# Altium schematic sheet MCP3561R_ADC.SchDoc(MCP3561R_ADC)
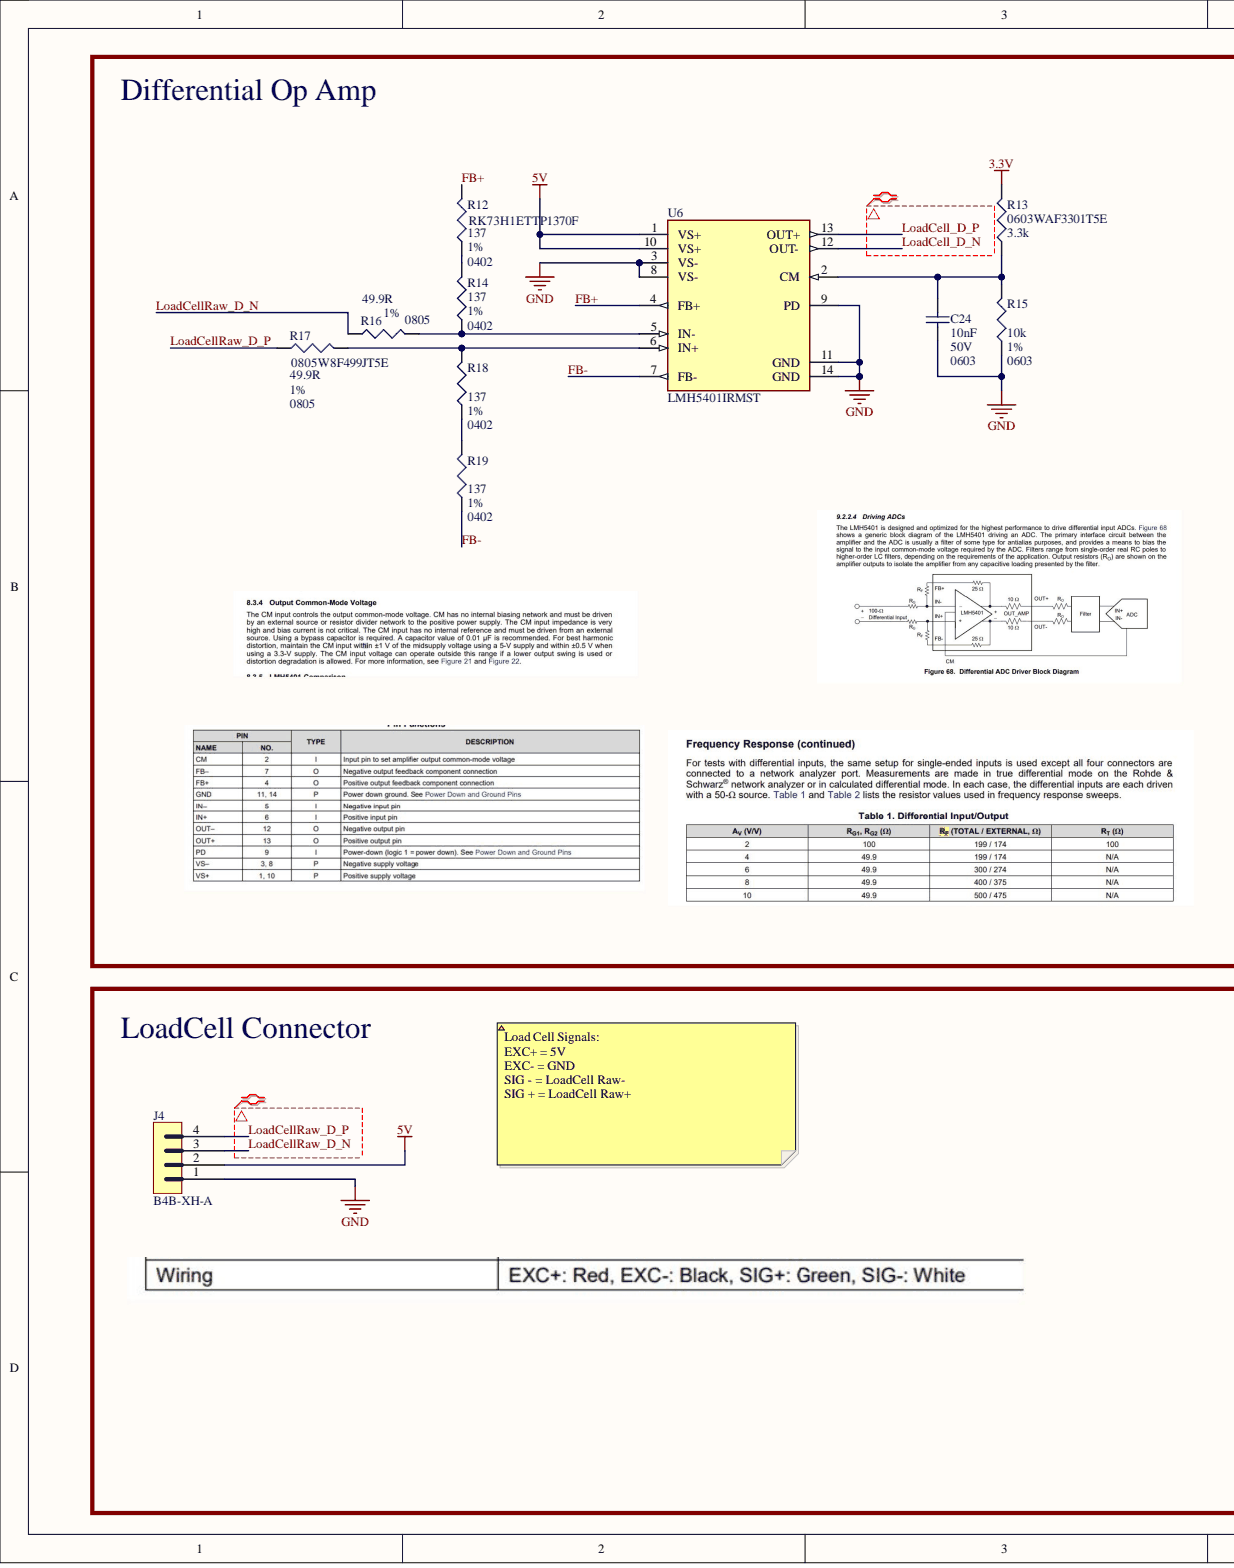
[diagram p0: MCP3561R_ADC.SchDoc, left]
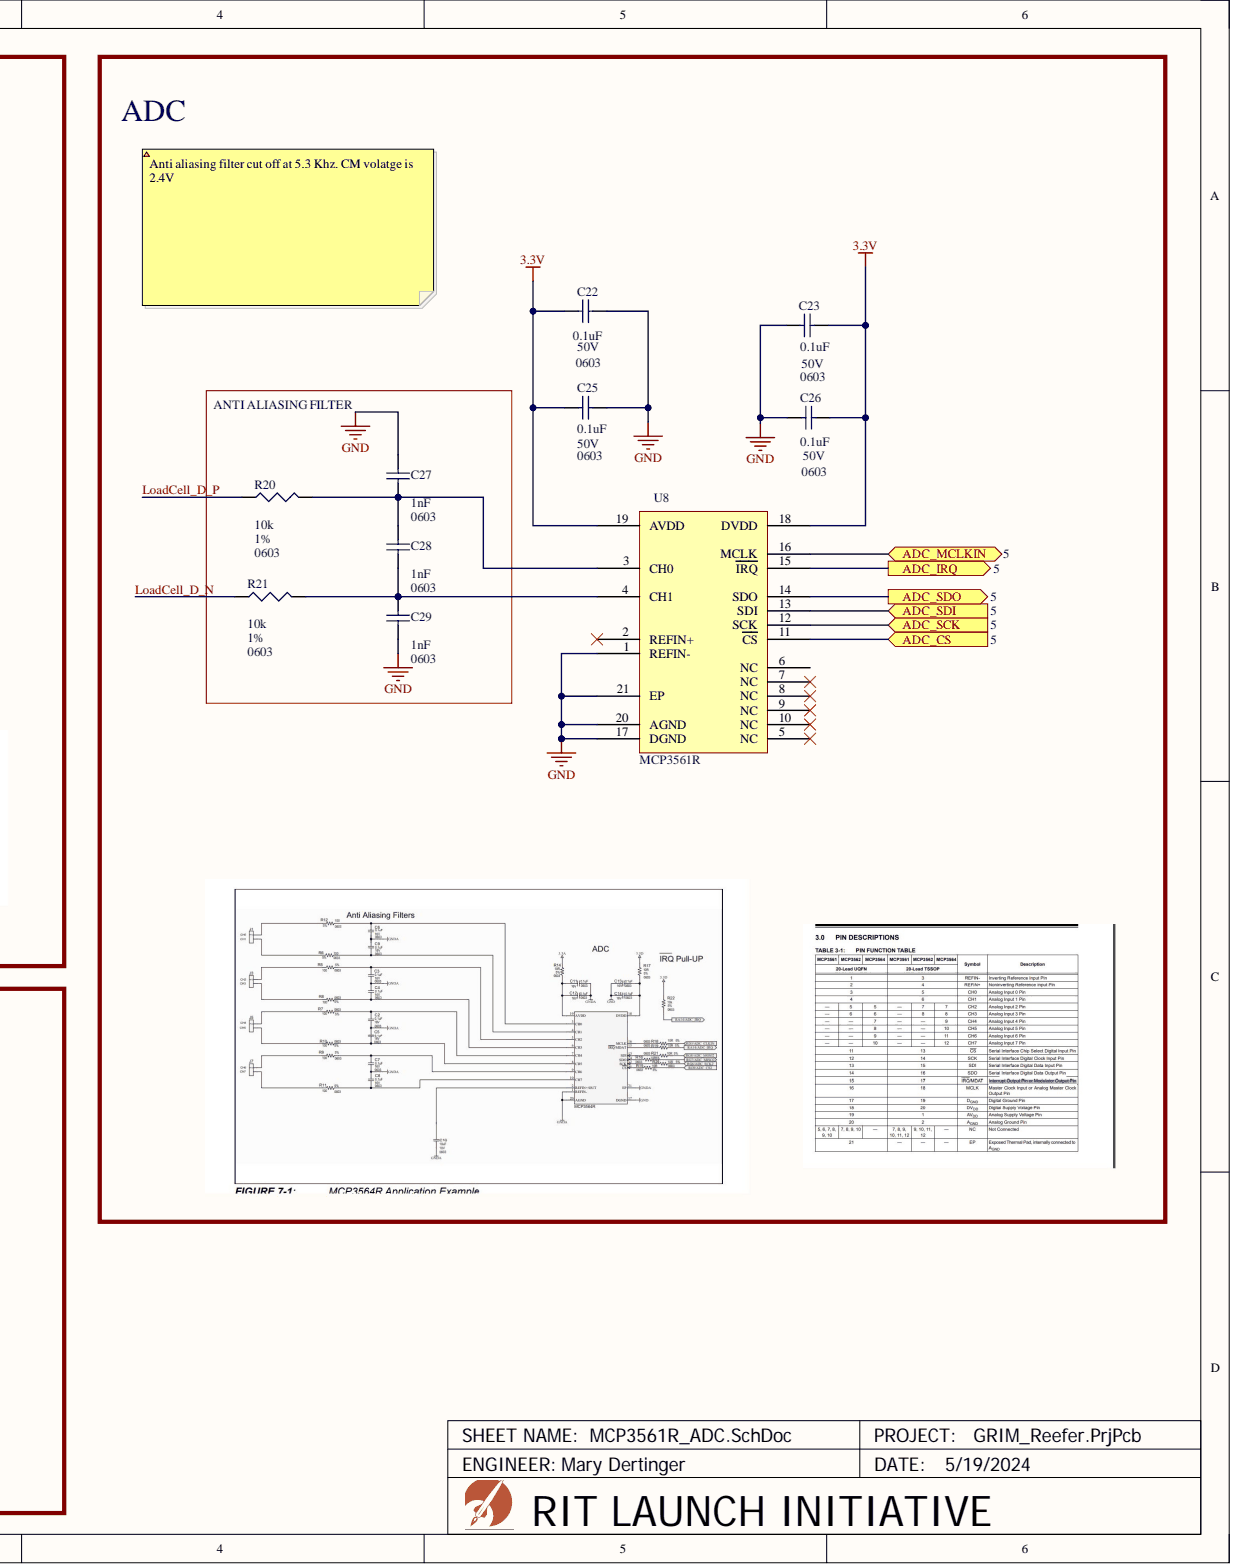
[diagram p1: MCP3561R_ADC.SchDoc, right]

## Parts
C22  Capacitance: 0.1uF  Voltage: 50V  (hidden comment: CC0603KRX7R9BB104)
C23  Capacitance: 0.1uF  Voltage: 50V  (hidden comment: CC0603KRX7R9BB104)
C24  Capacitance: 10nF  Case/Package: 0603  Voltage: 50V  (hidden comment: 0603B103K500NT)
C25  Capacitance: 0.1uF  Case Code (Imperial): 0603  Voltage: 50V  (hidden comment: CC0603KRX7R9BB104)
C26  Capacitance: 0.1uF  Case Code (Imperial): 0603  Voltage: 50V  (hidden comment: CC0603KRX7R9BB104)
C27  Capacitance: 1nF  (hidden comment: CL10B102KB8NNNC)
C28  Capacitance: 1nF  Case/Package: 0603  (hidden comment: CL10B102KB8NNNC)
C29  Capacitance: 1nF  Case/Package: 0603  (hidden comment: CL10B102KB8NNNC)
J4  B4B-XH-A
R12  RK73H1ETTP1370F  Case/Package: 0402  Tolerance: 1%
R13  0603WAF3301T5E  Resistance: 3.3k
R14  RK73H1ETTP1370F  Case/Package: 0402  Tolerance: 1%
R15  Case/Package: 0603  Resistance: 10k  Tolerance: 1%  (hidden comment: 0603WAF1002T5E)
R16  0805W8F499JT5E  Case/Package: 0805  Resistance: 49.9R  Tolerance: 1%
R17  0805W8F499JT5E  Resistance: 49.9R  Tolerance: 1%
R18  RK73H1ETTP1370F  Case/Package: 0402  Tolerance: 1%
R19  RK73H1ETTP1370F  Case/Package: 0402  Tolerance: 1%
R20  Resistance: 10k  Tolerance: 1%  (hidden comment: 0603WAF1002T5E)
R21  Case/Package: 0603  Resistance: 10k  Tolerance: 1%  (hidden comment: 0603WAF1002T5E)
U6  LMH5401IRMST
U8  MCP3561R

## Ports
ADC_CS, ADC_IRQ, ADC_MCLKIN, ADC_SCK, ADC_SDI, ADC_SDO

## Nets
3.3V: C22.1 C23.2 C25.1 C26.2 R13.2 U8.18(DVDD) U8.19(AVDD)
5V: J4.2 U6.1(VS+) U6.10(VS+)
GND: C22.2 C23.1 C24.1 C25.2 C26.1 C27.2 C29.1 J4.1 R15.1 U6.3(VS-) U6.8(VS-) U6.9(PD) U6.11(GND) U6.14(GND) U8.1(REFIN-) U8.17(DGND) U8.20(AGND) U8.21(EP)
ADC_CS: U8.11(CS) ; port ADC_CS
ADC_IRQ: U8.15(IRQ) ; port ADC_IRQ
ADC_MCLKIN: U8.16(MCLK) ; port ADC_MCLKIN
ADC_SCK: U8.12(SCK) ; port ADC_SCK
ADC_SDI: U8.13(SDI) ; port ADC_SDI
ADC_SDO: U8.14(SDO) ; port ADC_SDO
FB+: R12.2 U6.4(FB+)
FB-: R19.1 U6.7(FB-)
LoadCell_D_N: R21.1 U6.12(OUT-)
LoadCell_D_P: R20.1 U6.13(OUT+)
LoadCellRaw_D_N: J4.3 R16.1
LoadCellRaw_D_P: J4.4 R17.1
NetC24_2: C24.2 R13.1 R15.2 U6.2(CM)
NetC27_1: C27.1 C28.2 R20.2 U8.3(CH0)
NetC28_1: C28.1 C29.2 R21.2 U8.4(CH1)
NetR12_1: R12.1 R14.2
NetR14_1: R14.1 R16.2 U6.5(IN-)
NetR17_2: R17.2 R18.2 U6.6(IN+)
NetR18_1: R18.1 R19.2

Not connected: U8 2(REFIN+) 5(NC) 6(NC) 7(NC) 8(NC) 9(NC) 10(NC)

## Library data of the parts
C22 C23 C25 C26 = CMP-001-0242-3: CAP CER 0.1UF 50V X7R 0603; Dielectric Material: Ceramic; Tolerance: 10%; Case Code (Metric): 1608; Case/Package: 0603; Component Kind: Standard; Depth: 0.8mm; Dielectric: X7R; Halogen Free: Halogen Free; Height: 0.8mm; JLCPCB Part #: C14663; Lead Free: Lead Free; Length: 0.063inch; Max Operating Temperature: 125°C; Min Operating Temperature: -55°C; Mount: Surface Mount; Number of Pins: 2; Package Quantity: 1; Packaging: Tape and Reel; Radiation Hardening: No; REACH SVHC: No SVHC; RoHS Compliant: Yes; Series: CC; Termination: SMD/SMT; Thickness: 0.035inch; Voltage Rating: 50V; Width: 0.031inch; Library Name: RIT Launch Initiative
  C22 C23: Case Code (Imperial): 0603
C24 = CMP-001-0273-1: CAP CER 10000PF 50V X7R 0603; Component Kind: Standard; JLCPCB Part #: C57112; Library Name: RIT Launch Initiative
C27-C29 = CMP-001-0398-1: Ceramic Capacitor, Multilayer, Ceramic, 50V, 10% +Tol, 10% -Tol, X7R, 15% TC, 0.001uF, Surface Mount, 0603; Multilayer: Yes; Capacitor Type: Ceramic; Component Kind: Standard; Dielectric Material: Ceramic; Height: 0.9mm; JESD-609 Code: e3; JLCPCB Part #: C1588; Length: 1.6mm; Max Operating Temperature: 125°C; Min Operating Temperature: -55°C; Mounting Technology: Surface Mount; Package Shape: Rectangular; Package Style: SMTMeter; Packaging: Tape and Reel; Pins: 2; Rated DC Voltage (URdc): 50V; Reach SVHC Compliant: Yes; RoHS Compliant: Yes; Temperature Characteristics Code: X7R; Terminal Finish: Nickel; Termination: Wraparound; Tolerance  (fill in as +-): 10%; Tolerance (fill in as +-): 10%; Width: 0.8mm; Library Name: RIT Launch Initiative
  C27: Case/Package: 0603
J4 = CMP-001-0397-1: CONN HEADER VERT 4POS 2.5MM; Component Kind: Standard; Library Name: RIT Launch Initiative
R12 R14 R18 R19 = CMP-001-0391-2: Fixed Resistor, Metal Glaze/thick Film, 0.1W, 137ohm, 75V, 1% +/-Tol, 100ppm/Cel, Surface Mount, 0402; Additional Feature: PRECISION; Package Height: 0.35mm; Package Length: 1mm; Package Width: 0.5mm; Power: 100mW; Rated Temperature: 70°C; Reference Standard: AEC-Q200; Resistance: 137; Temperature Coefficient: 100ppm/°C; Value: 137R; Working Voltage: 50V; Component Kind: Standard; Construction: Rectangular; JESD-609 Code: e3; JLCPCB Part #: C316926; Max Operating Temperature: 155°C; Min Operating Temperature: -55°C; Mounting Technology: Surface Mount; Package Style: SMTMeter; Packaging: Tape and Reel; Pins: 2; Reach SVHC Compliant: Yes; Resistor Type: FIXED RESISTOR; RoHS Compliant: Yes; Technology: METAL GLAZE/THICK FILM; Terminal Finish: Nickel; Termination: Wraparound; Library Name: RIT Launch Initiative
R13 = CMP-001-0414-1: Fixed Resistor, Metal Glaze/thick Film, 0.1W, 3300ohm, 75V, 1% +/-Tol, 100ppm/Cel, Surface Mount, 0603; Component Kind: Standard; JLCPCB Part #: C22978; Package: 0603; Reach SVHC Compliant: Yes; RoHS Compliant: Yes; Library Name: RIT Launch Initiative
R15 R20 R21 = CMP-001-0268-1: RES 10K OHM 1% 1/10W 0603; Component Kind: Standard; JLCPCB Part #: C25804; RoHS Compliant: Yes; Library Name: RIT Launch Initiative
  R20: Case/Package: 0603
R16 R17 = CMP-001-0415-2: Fixed Resistor, Metal Glaze/thick Film, 0.125W, 49.9ohm, 150V, 1% +/-Tol, 200ppm/Cel, Surface Mount, 0805; Manufacturer Series: 0805W8; Power: 125mW; Rated Temperature: 70°C; Temperature Coefficient: 200ppm/°C; Component Kind: Standard; JESD-609 Code: e3; JLCPCB Part #: C17720; Max Operating Temperature: 155°C; Min Operating Temperature: -55°C; Mounting Technology: Surface Mount; Package Shape: Rectangular; Packaging: Tape and Reel; Pins: 2; Resistor Type: FIXED RESISTOR; RoHS Compliant: Yes; Technology: METAL GLAZE/THICK FILM; Terminal Finish: Tin; Termination: Wraparound; Working Voltage: 150V; Library Name: RIT Launch Initiative
  R17: Case/Package: 0805
U6 = CMP-001-0375-3: Operational Amplifier, 1 Func, 5000uV Offset-Max, 4400MHz Band Width, BICMOS; Channels: 1; Common-mode Reject Ratio-Nom: 72dB; Nominal Supply Voltage: 5V; Slew Rate: 17500V/µs; Supply Voltage Limit-Max: 5.5V; Unity Gain BW-Nom: 8GHz; Amplifier Type: OPERATIONAL AMPLIFIER; Case/Package: VQCCN; Component Kind: Standard; Height - Seated (Max): 0.6mm; JESD-609 Code: e3; JLCPCB Part #: C2057542; Length: 2.5mm; Max Operating Temperature: 85°C; Min Operating Temperature: -40°C; Moisture Sensitivity Level: 2; Package Shape: Square; Package Style: CHIP CARRIER, VERY THIN PROFILEMeter; Pins: 14; Reach SVHC Compliant: Yes; RoHS Compliant: Yes; Surface Mount: Yes; Technology: BICMOS; Temperature Grade: INDUSTRIAL; Terminal Finish: Tin; Terminal Form: No Lead; Terminal Pitch: 0.5mm; Terminal Position: QUAD; Width: 2.5mm; Library Name: RIT Launch Initiative
U8 = CMP-001-0373-8: ADC, Delta-Sigma, 24-Bit, 1 Func, 1 Channel, Serial Access, PDSO20; Component Kind: Standard; Data SHeet: https://ww1.microchip.com/downloads/en/DeviceDoc/MCP3561.2.4R-Data-Sheet-DS200006391A.pdf; RoHS Compliant: Yes; Library Name: RIT Launch Initiative
